# Altium schematic sheet [3]-MCU.SchDoc([3]-MCU)
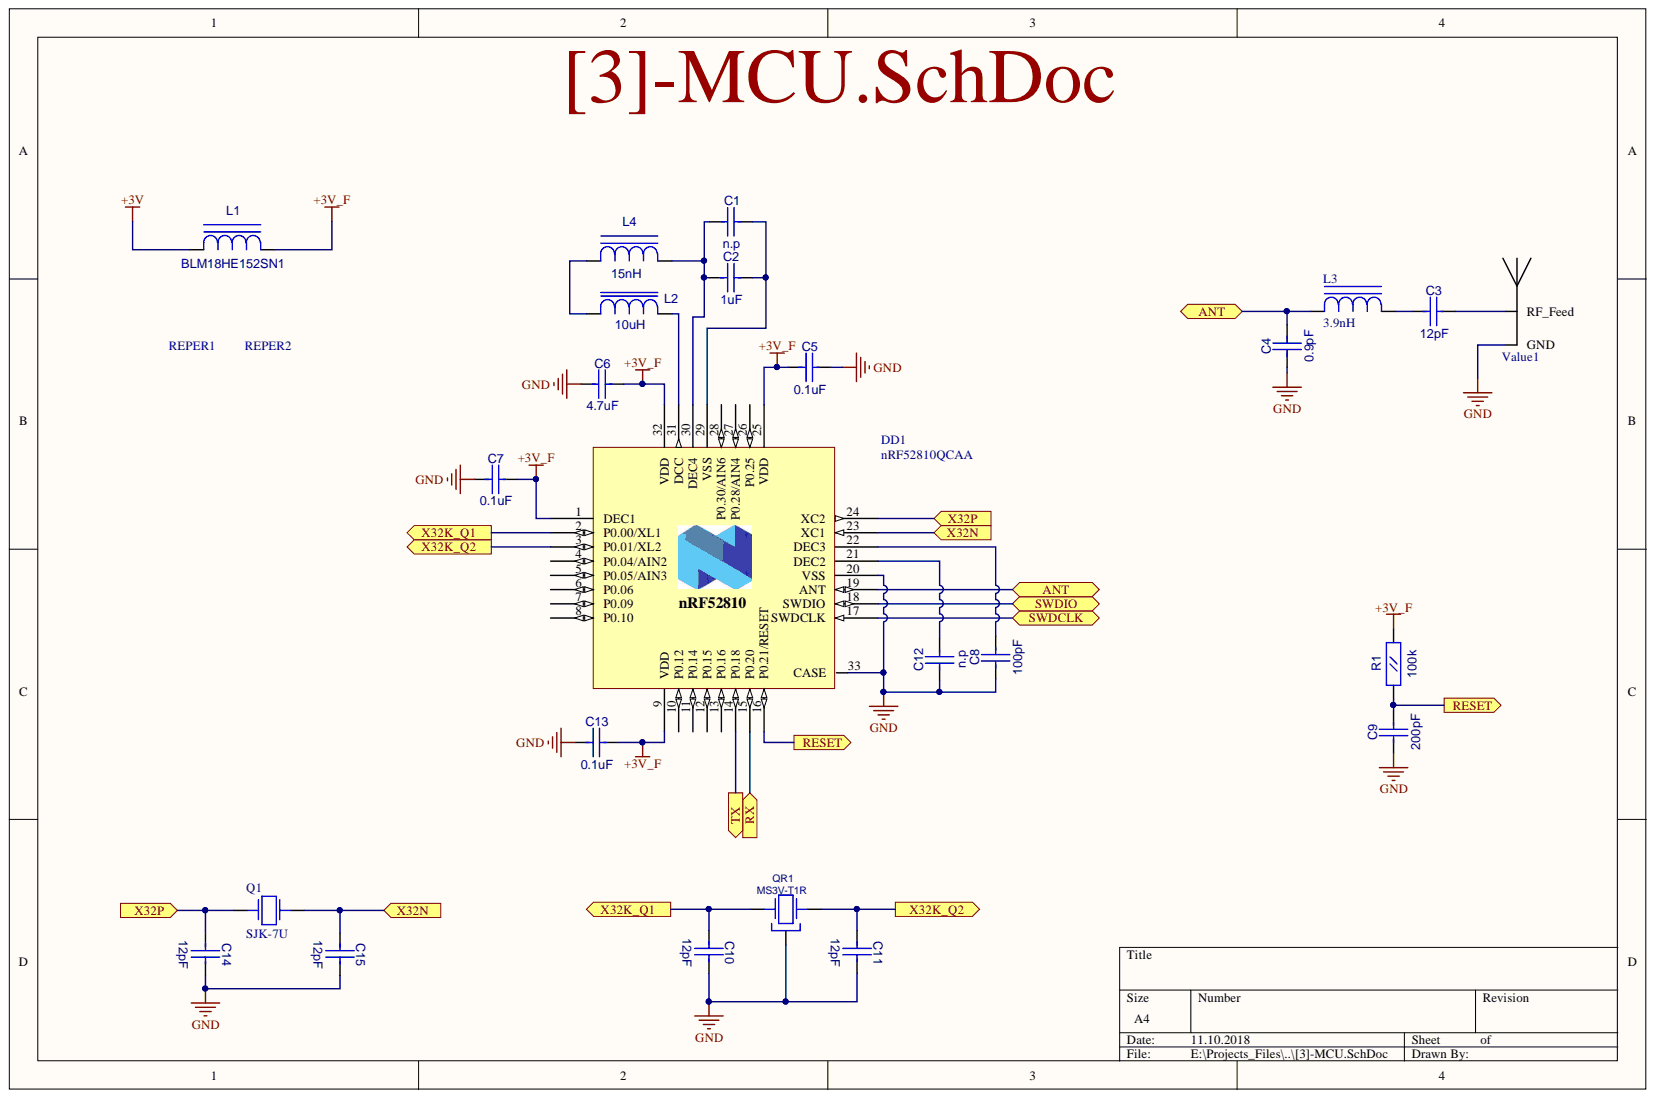
[diagram p0: [3]-MCU.SchDoc]

## Parts
C1  n.p  [CC0805]
C2  1uF  [CC0603]
C3  12pF  [CC0603]
C4  0.9pF  [CC0603]
C5  0.1uF  [CC0603]
C6  4.7uF  [CC0805]
C7  0.1uF  [CC0603]
C8  100pF  [CC0603]
C9  200pF  [CC0603]
C10  12pF  [CC0603]
C11  12pF  [CC0603]
C12  n.p  [CC0603]
C13  0.1uF  [CC0603]
C14  12pF  [CC0603]
C15  12pF  [CC0603]
DD1  nRF52810QCAA  [QFN-32]
L1  BLM18HE152SN1  [L0603]
L2  10uH  [RC0805]
L3  3.9nH  [L0402]
L4  value 15nH  [L0603]  (hidden comment: L0603)
Q1  SJK-7U  [QUARTZ_3225_4]
QR1  MS3V-T1R  [MS3V-T1R]
R1  100k  [RC0603]
REPER1  REPER1.2  [REPER1.2]  (value not drawn)
REPER2  REPER1.2  [REPER1.2]  (value not drawn)
Value1  ANTENNA_IFA_245_1MM  [IFA245_1mm]  (value not drawn)

## Ports
ANT, RESET, RX, SWDCLK, SWDIO, TX, X32K_Q1, X32K_Q2, X32N, X32P

## Nets
+3V: L1.1
+3V_F: C5.1 C6.2 C7.2 C13.2 DD1.1(DEC1) DD1.9(VDD) DD1.25(VDD) DD1.32(VDD) L1.2 R1.2
GND: C4.1 C5.2 C6.1 C7.1 C8.1 C9.1 C10.2 C11.2 C12.1 C13.1 C14.2 C15.2 DD1.20(VSS) DD1.33(CASE) QR1.3 Value1.2
ANT: C4.2 DD1.19(ANT) L3.1 ; port ANT
RESET: C9.2 DD1.16(P0.21/RESET) R1.1 ; port RESET
RX: DD1.15(P0.20) ; port RX
SWDCLK: DD1.17(SWDCLK) ; port SWDCLK
SWDIO: DD1.18(SWDIO) ; port SWDIO
TX: DD1.14(P0.18) ; port TX
X32K_Q1: C10.1 DD1.2(P0.00/XL1) QR1.2 ; port X32K_Q1
X32K_Q2: C11.1 DD1.3(P0.01/XL2) QR1.1 ; port X32K_Q2
X32N: C15.1 DD1.23(XC1) Q1.3 ; port X32N
X32P: C14.1 DD1.24(XC2) Q1.1 ; port X32P
NetC1_1: C1.1 C2.1 DD1.30(DEC4) L4.2
NetC1_2: C1.2 C2.2 DD1.29(VSS)
NetC3_1: C3.1 L3.2
NetC3_2: C3.2 Value1.1
NetC8_2: C8.2 DD1.22(DEC3)
NetC12_2: C12.2 DD1.21(DEC2)
NetDD1_31: DD1.31(DCC) L2.2
NetL2_1: L2.1 L4.1

Not connected: DD1 4(P0.04/AIN2) 5(P0.05/AIN3) 6(P0.06) 7(P0.09) 8(P0.10) 10(P0.12) 11(P0.14) 12(P0.15) 13(P0.16) 26(P0.25) 27(P0.28/AIN4) 28(P0.30/AIN6)

## Library data of the parts
C1 C6 = CC0805: Description: CC0805; Component Kind: Standard; Library Name: fizik_lib.SchLib
  C1: Value: n.p
  C6: Value: 4.7uF
C2-C5 C7-C15 = CC0603: Description: CC0603; Component Kind: Standard
  C2: Value: 1uF
  C3 C10 C11 C14 C15: Value: 12pF
  C4: Value: 0.9pF
  C5 C7 C13: Value: 0.1uF
  C8: Value: 100pF
  C9: Value: 200pF
  C12: Value: n.p
  C2 C5 C7-C15: Library Name: gprs_modem_2.SchLib
  C3 C4: Library Name: fizik_lib.SchLib
DD1 = NRF52810QCAA: BLE 5 controller; Value: nRF52810QCAA; Component Kind: Standard; Library Name: fizik_lib.SchLib
L1 = L0603: Value: BLM18HE152SN1; Description: L0603; Component Kind: Standard; Library Name: gprs_modem_2.SchLib
L2 = L0805: Value: 10uH; Description: L0805; Component Kind: Standard; Library Name: gprs_modem_2.SchLib
L3 = L0402: Value: 3.9nH; Description: L0402; Component Kind: Standard; Library Name: gprs_modem_2.SchLib
Q1 = SJK-7U: 3.2x2.5mm 4 pin SMD quartz crystal; Value: SJK-7U; Component Kind: Standard; Library Name: fizik_lib.SchLib
QR1 = MS3V-T1R: CRYSTAL_32768 Hz; Component Kind: Standard; Library Name: gprs_modem_2.SchLib
R1 = RC0603: Value: 100k; Description: RC0603; Component Kind: Standard; Library Name: gprs_modem_2.SchLib
Value1 = ANTENNA_IFA_245_1MM: Antenna 2.45GHz; Value: IFA 2.45GHz; Component Kind: Standard; Library Name: fizik_lib.SchLib
L4 = L0603: Description: L0603; Component Kind: Standard; Library Name: fizik_lib.SchLib
REPER1 REPER2 = REPER1.2: Description: REPER1.2; Component Kind: Standard; Library Name: gprs_modem_2.SchLib
